# Altium schematic sheet xcvr.SchDoc(U_xcvr)
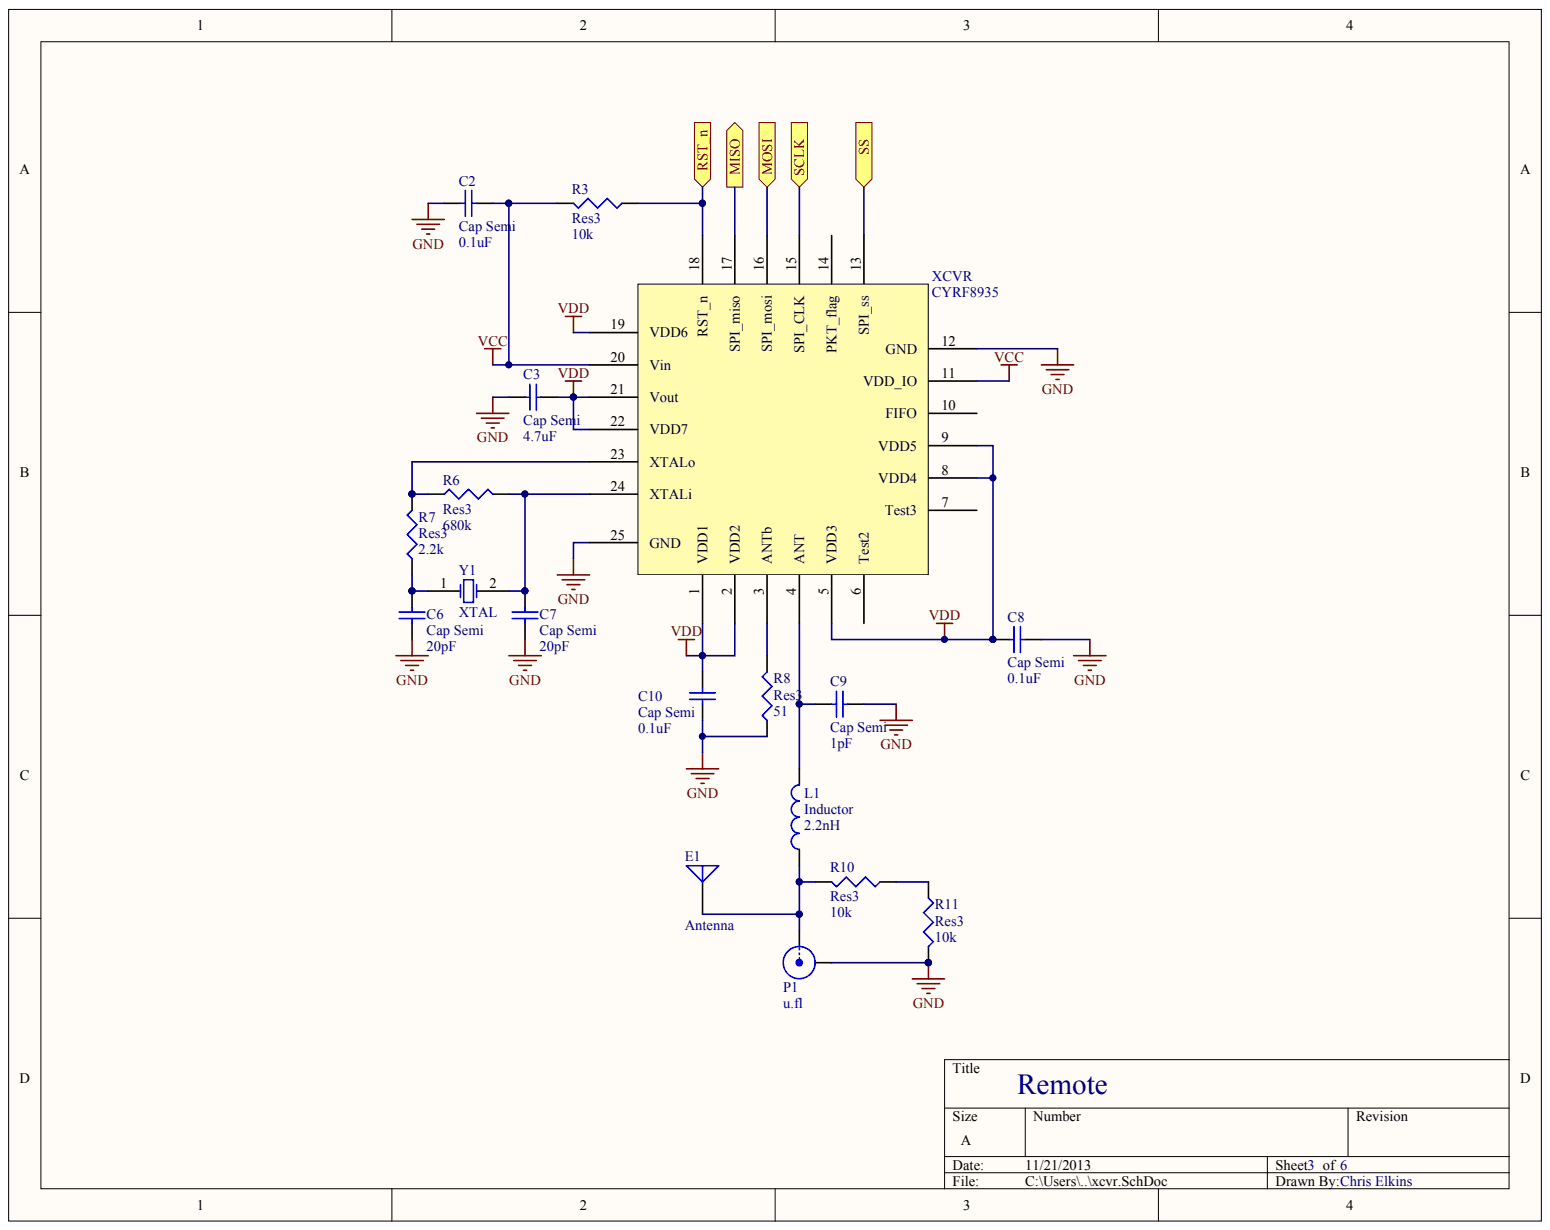
[diagram p0: xcvr.SchDoc]

## Parts
C2  Cap Semi  value 0.1uF
C3  Cap Semi  value 4.7uF
C6  Cap Semi  value 20pF
C7  Cap Semi  value 20pF
C8  Cap Semi  value 0.1uF
C9  Cap Semi  value 1pF
C10  Cap Semi  value 0.1uF
E1  Antenna
L1  Inductor  value 2.2nH
P1  u.fl
R3  Res3  value 10k
R6  Res3  value 680k
R7  Res3  value 2.2k
R8  Res3  value 51
R10  Res3  value 10k
R11  Res3  value 10k
XCVR  CYRF8935
Y1  XTAL

## Ports
MISO, MOSI, RST_N, SCLK, SS

## Nets
GND: C2.1 C3.1 C6.1 C7.1 C8.1 C9.2 C10.2 P1.2 R8.1 R11.2 XCVR.12(GND) XCVR.25(GND)
VCC: C2.2 R3.2 XCVR.11(VDD_IO) XCVR.20(Vin)
VDD: C3.2 C8.2 C10.1 XCVR.1(VDD1) XCVR.2(VDD2) XCVR.5(VDD3) XCVR.8(VDD4) XCVR.9(VDD5) XCVR.19(VDD6) XCVR.21(Vout) XCVR.22(VDD7)
MISO: XCVR.17(SPI_miso) ; port MISO
MOSI: XCVR.16(SPI_mosi) ; port MOSI
SCLK: XCVR.15(SPI_CLK) ; port SCLK
SS: XCVR.13(SPI_ss) ; port SS
NetC6_2: C6.2 R7.1 Y1.1
NetC7_2: C7.2 R6.2 XCVR.24(XTALi) Y1.2
NetC9_1: C9.1 L1.2 XCVR.4(ANT)
NetE1_1: E1.1 L1.1 P1.1 R10.2
NetR3_1: R3.1 XCVR.18(RST_n) ; port RST_N
NetR6_1: R6.1 R7.2 XCVR.23(XTALo)
NetR8_2: R8.2 XCVR.3(ANTb)
NetR10_1: R10.1 R11.1

Not connected: XCVR 6(Test2) 7(Test3) 10(FIFO) 14(PKT_flag)

## Library data of the parts
L1 = (no symbol name): Code_IEC: 1005; Published: 8-Jun-2000; LatestRevisionDate: 29-May-2009; LatestRevisionNote: IPC-7351 Footprint Added.; PackageReference: 0402-A; PackageDescription: Chip Inductor; Code_JEITA: 0402; Publisher: Altium Limited
R3 R10 = (no symbol name): Value: 10k; Published: 8-Jun-2000; LatestRevisionDate: 29-May-2009; LatestRevisionNote: IPC-7351 Footprint Added.; PackageReference: J1-0603; PackageDescription: Chip Resistor; Publisher: Altium Limited
R6 = (no symbol name): Value: 680k; Published: 8-Jun-2000; LatestRevisionDate: 29-May-2009; LatestRevisionNote: IPC-7351 Footprint Added.; PackageReference: J1-0603; PackageDescription: Chip Resistor; Publisher: Altium Limited
C2 C3 C6-C10 E1 P1 R7 R8 R11 XCVR Y1 = (no symbol name)
  C2 C3 C6-C10: Published: 8-Jun-2000; LatestRevisionDate: 29-May-2009; LatestRevisionNote: IPC-7351 Footprint Added.; PackageReference: C1206; PackageDescription: Chip Capacitor
  E1: Published: 24-Mar-1999; LatestRevisionDate: 17-Jul-2002; LatestRevisionNote: Re-released for DXP Platform.; PackageReference: PIN1
  P1: LatestRevisionDate: 17-Jul-2002; LatestRevisionNote: Re-released for DXP Platform.
  R7 R8 R11: Published: 8-Jun-2000; LatestRevisionDate: 29-May-2009; LatestRevisionNote: IPC-7351 Footprint Added.; PackageReference: J1-0603; PackageDescription: Chip Resistor
  Y1: Published: 8-Jun-2000; LatestRevisionDate: 17-Jul-2002; LatestRevisionNote: Re-released for DXP Platform.; PackageReference: R38
  C2 C3 C6-C10 E1 P1 R7 R8 R11 Y1: Publisher: Altium Limited
